# Altium schematic sheet TOP.SchDoc(TOP)
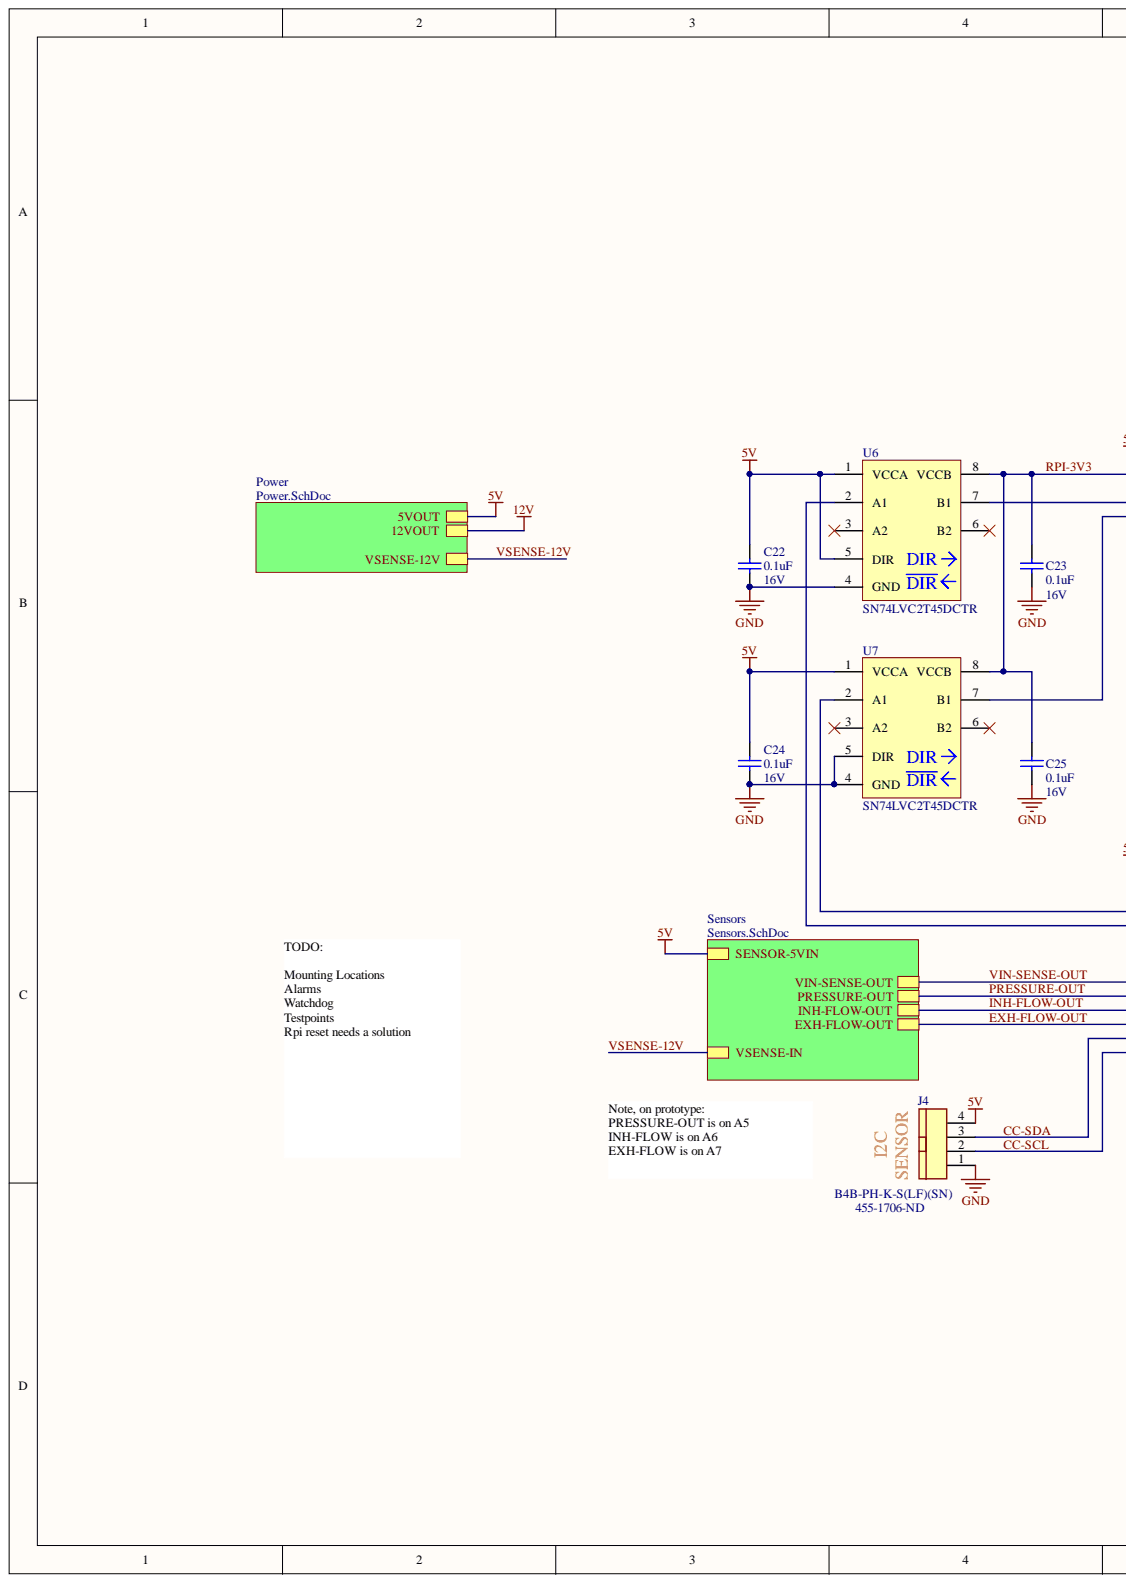
[diagram p0: TOP.SchDoc, left]
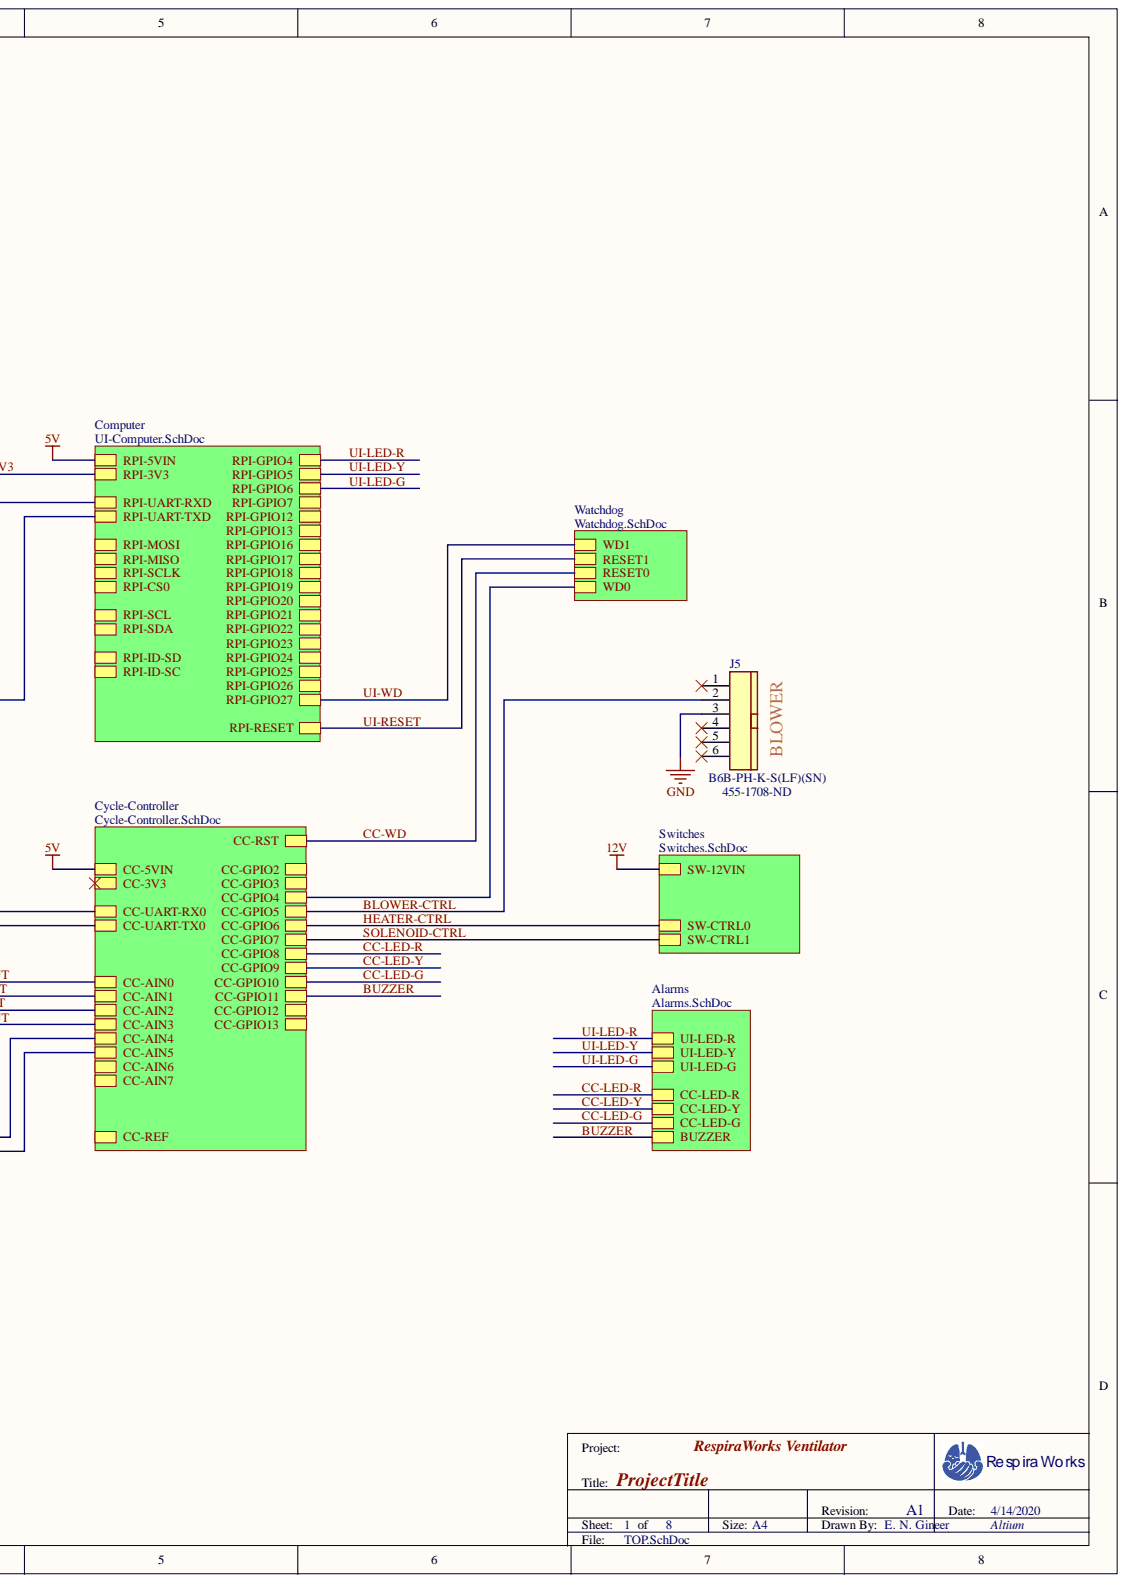
[diagram p1: TOP.SchDoc, right]

## Parts
C22  value 0.1uF  Voltage: 16V  [CAP0603]  (hidden comment: CAP 0603)
C23  value 0.1uF  Voltage: 16V  [CAP0603]  (hidden comment: CAP 0603)
C24  value 0.1uF  Voltage: 16V  [CAP0603]  (hidden comment: CAP 0603)
C25  value 0.1uF  Voltage: 16V  [CAP0603]  (hidden comment: CAP 0603)
J4  B4B-PH-K-S(LF)(SN)  Supplier Part Number 1: 455-1706-ND  [JST_B4B-XH-AM]
J5  B6B-PH-K-S(LF)(SN)  Supplier Part Number 1: 455-1708-ND  [JST_B6B-XH-AM]
U6  SN74LVC2T45DCTR  [8-MSOP]
U7  SN74LVC2T45DCTR  [8-MSOP]

## Nets
5V: C22.1 C24.1 J4.4 U6.1(VCCA) U6.5(DIR) U7.1(VCCA)
GND: C22.2 C23.2 C24.2 C25.2 J4.1 J5.3 U6.4(GND) U7.4(GND) U7.5(DIR)
BLOWER-CTRL: J5.2
CC-SCL: J4.2
CC-SDA: J4.3
RPI-3V3: C23.1 C25.1 U6.8(VCCB) U7.8(VCCB)
NetJ5_1: J5.1
NetJ5_4: J5.4
NetJ5_5: J5.5
NetJ5_6: J5.6
NetU6_2: U6.2(A1)
NetU6_3: U6.3(A2)
NetU6_6: U6.6(B2)
NetU6_7: U6.7(B1)
NetU7_2: U7.2(A1)
NetU7_3: U7.3(A2)
NetU7_6: U7.6(B2)
NetU7_7: U7.7(B1)
12V:
BUZZER:
CC-3V3:
CC-AIN6:
CC-AIN7:
CC-GPIO2:
CC-GPIO3:
CC-GPIO4:
CC-GPIO12:
CC-GPIO13:
CC-LED-G:
CC-LED-R:
CC-LED-Y:
CC-REF:
CC-WD:
EXH-FLOW-OUT:
HEATER-CTRL:
INH-FLOW-OUT:
PRESSURE-OUT:
RPI-CS0:
RPI-GPIO7:
RPI-GPIO12:
RPI-GPIO13:
RPI-GPIO16:
RPI-GPIO17:
RPI-GPIO18:
RPI-GPIO19:
RPI-GPIO20:
RPI-GPIO21:
RPI-GPIO22:
RPI-GPIO23:
RPI-GPIO24:
RPI-GPIO25:
RPI-GPIO26:
RPI-ID-SC:
RPI-ID-SD:
RPI-MISO:
RPI-MOSI:
RPI-SCL:
RPI-SCLK:
RPI-SDA:
SOLENOID-CTRL:
UI-LED-G:
UI-LED-R:
UI-LED-Y:
UI-RESET:
UI-WD:
VIN-SENSE-OUT:
VSENSE-12V:

## Library data of the parts
C22-C25 = CAP 0603: Manufacturer P/N: CC0603KRX7R7BB104; Manufacturer: Yageo; Type: X7R; PCB Package: 0603; Library Name: generic.SchLib; Description: Cap 0603; Component Kind: Standard
J4 = B4B-PH-K-S(LF)(SN): CONN HEADER PH TOP 4POS 2MM; Manufacturer: JST Sales America Inc.; Pitch: 0.079" (2.00mm); Number of Positions Loaded: All; Number of Positions: 4; Connector Type: Header, Shrouded; Termination: Press-Fit, Solder; Supplier 1: Digi-Key; Stock 1: 70320; Row Spacing: -; RoHS: RoHS Compliant; Pricing 1: 1=0.24, 10=0.227, 100=0.1573, 500=0.13312, 1000=0.10892, 5000=0.09682, 10000=0.08774 (USD); Part Status: Active; Packaging: Bulk; Number of Rows: 1; Mounting Type: Through Hole; Manufacturer Part Number: B4B-PH-K-S(LF)(SN); Library Name: JST XH.SchLib; Features: -; Fastening Type: Detent Lock; Contact Type: Male Pin; Contact Mating Length: 0.130" (3.30mm); Contact Finish Thickness: -; Contact Finish: Tin; ComponentLink1URL: http://www.jst-mfg.com/product/pdf/eng/ePH.pdf; ComponentLink1Description: http://www.jst-mfg.com/product/pdf/eng/ePH.pdf; Component Kind: Standard; Color: Natural; Category: Connectors, Interconnects
J5 = B6B-PH-K-S(LF)(SN): CONN HEADER PH TOP 6POS 2MM; Manufacturer: JST Sales America Inc.; Pitch: 0.079" (2.00mm); Number of Positions Loaded: All; Number of Positions: 6; Connector Type: Header, Shrouded; Termination: Press-Fit, Solder; Supplier 1: Digi-Key; Stock 1: 30111; Row Spacing: -; RoHS: RoHS Compliant; Pricing 1: 1=0.33, 10=0.313, 100=0.2194, 500=0.18808, 1000=0.15674, 5000=0.13435, 10000=0.12539 (USD); Part Status: Active; Packaging: Bulk; Number of Rows: 1; Mounting Type: Through Hole; Manufacturer Part Number: B6B-PH-K-S(LF)(SN); Library Name: JST XH.SchLib; Features: -; Fastening Type: Detent Lock; Contact Type: Male Pin; Contact Mating Length: 0.130" (3.30mm); Contact Finish Thickness: -; Contact Finish: Tin; ComponentLink1URL: http://www.jst-mfg.com/product/pdf/eng/ePH.pdf; ComponentLink1Description: http://www.jst-mfg.com/product/pdf/eng/ePH.pdf; Component Kind: Standard; Color: Natural; Category: Connectors, Interconnects
U6 U7 = SN74LVC2T45DCTR: Bidirectional Level Shifter 2-ch; Manufacturer: Texas Instruments; PCB Package: 16-TSSOP; Manufacturer P/N: SN74LVC2T45DCTR; Library Name: ti.SchLib; Component Kind: Standard
